# Altium schematic sheet Sheet2.SchDoc(Sheet2)
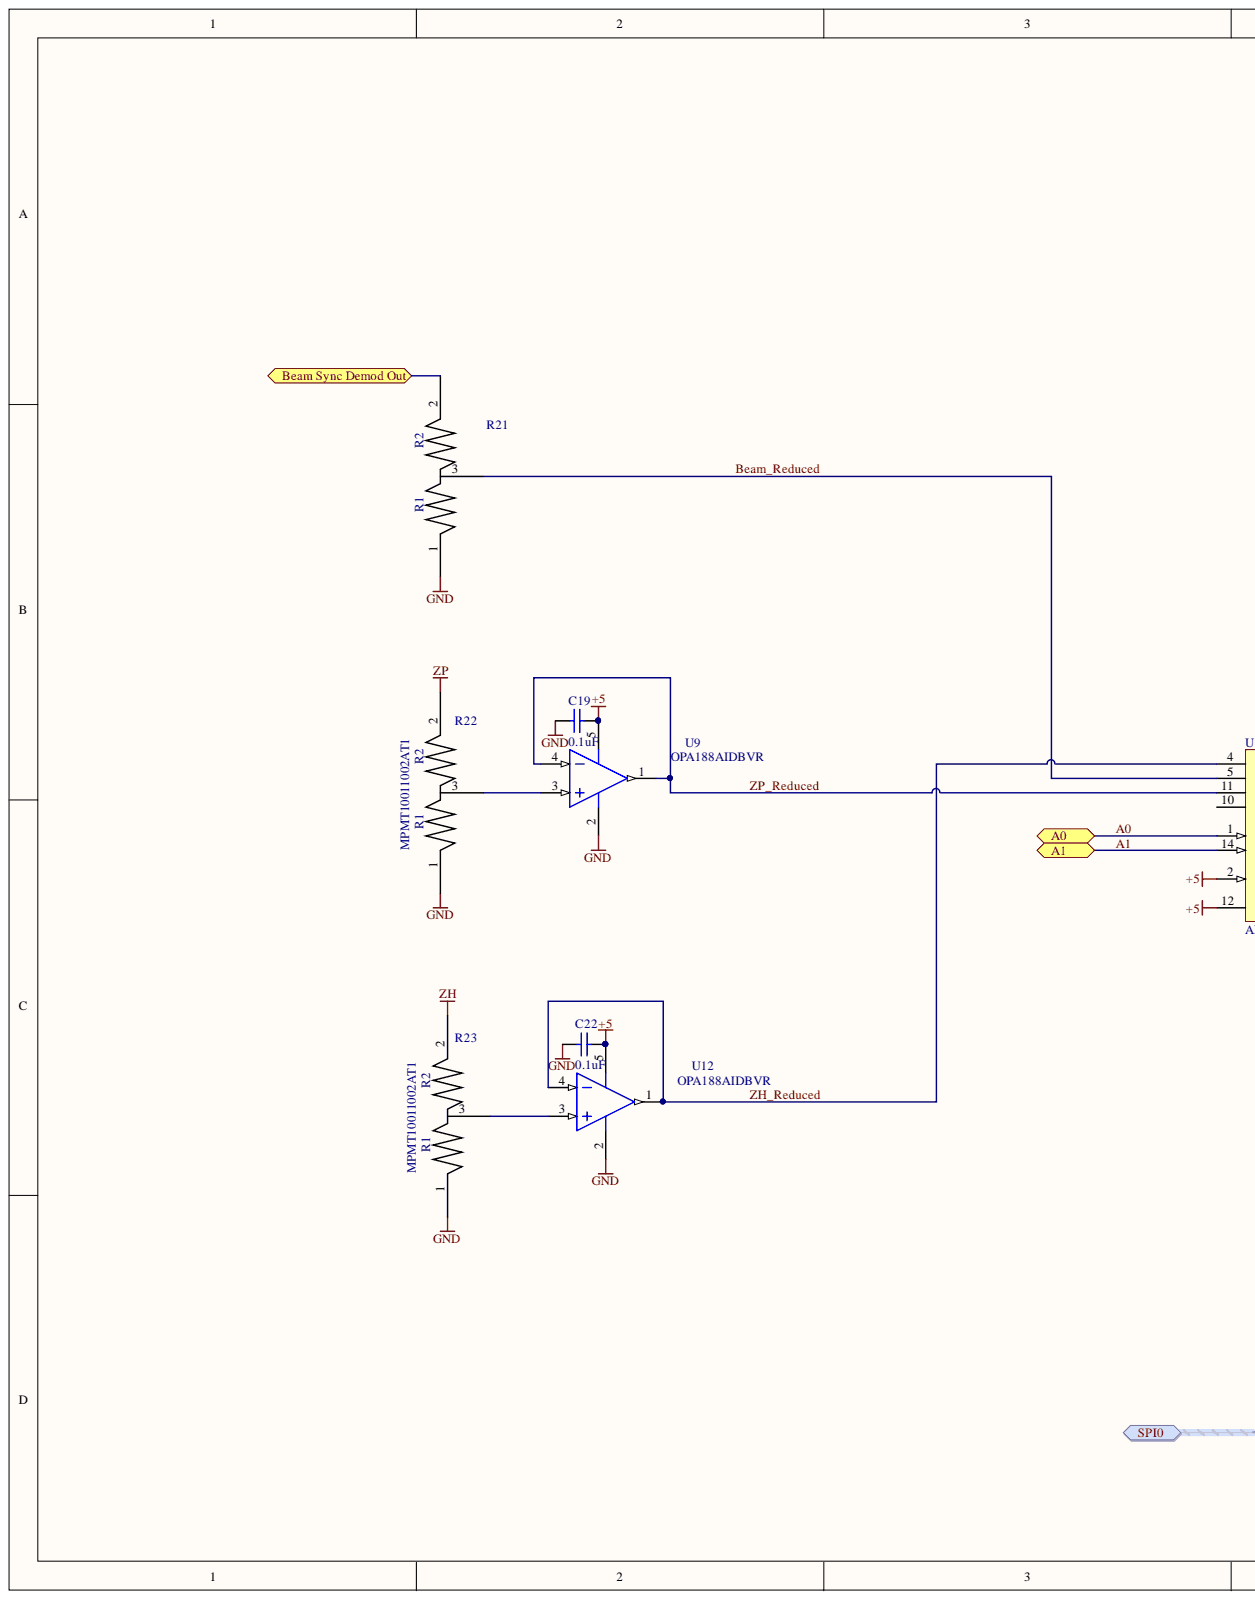
[diagram p0: Sheet2.SchDoc, left]
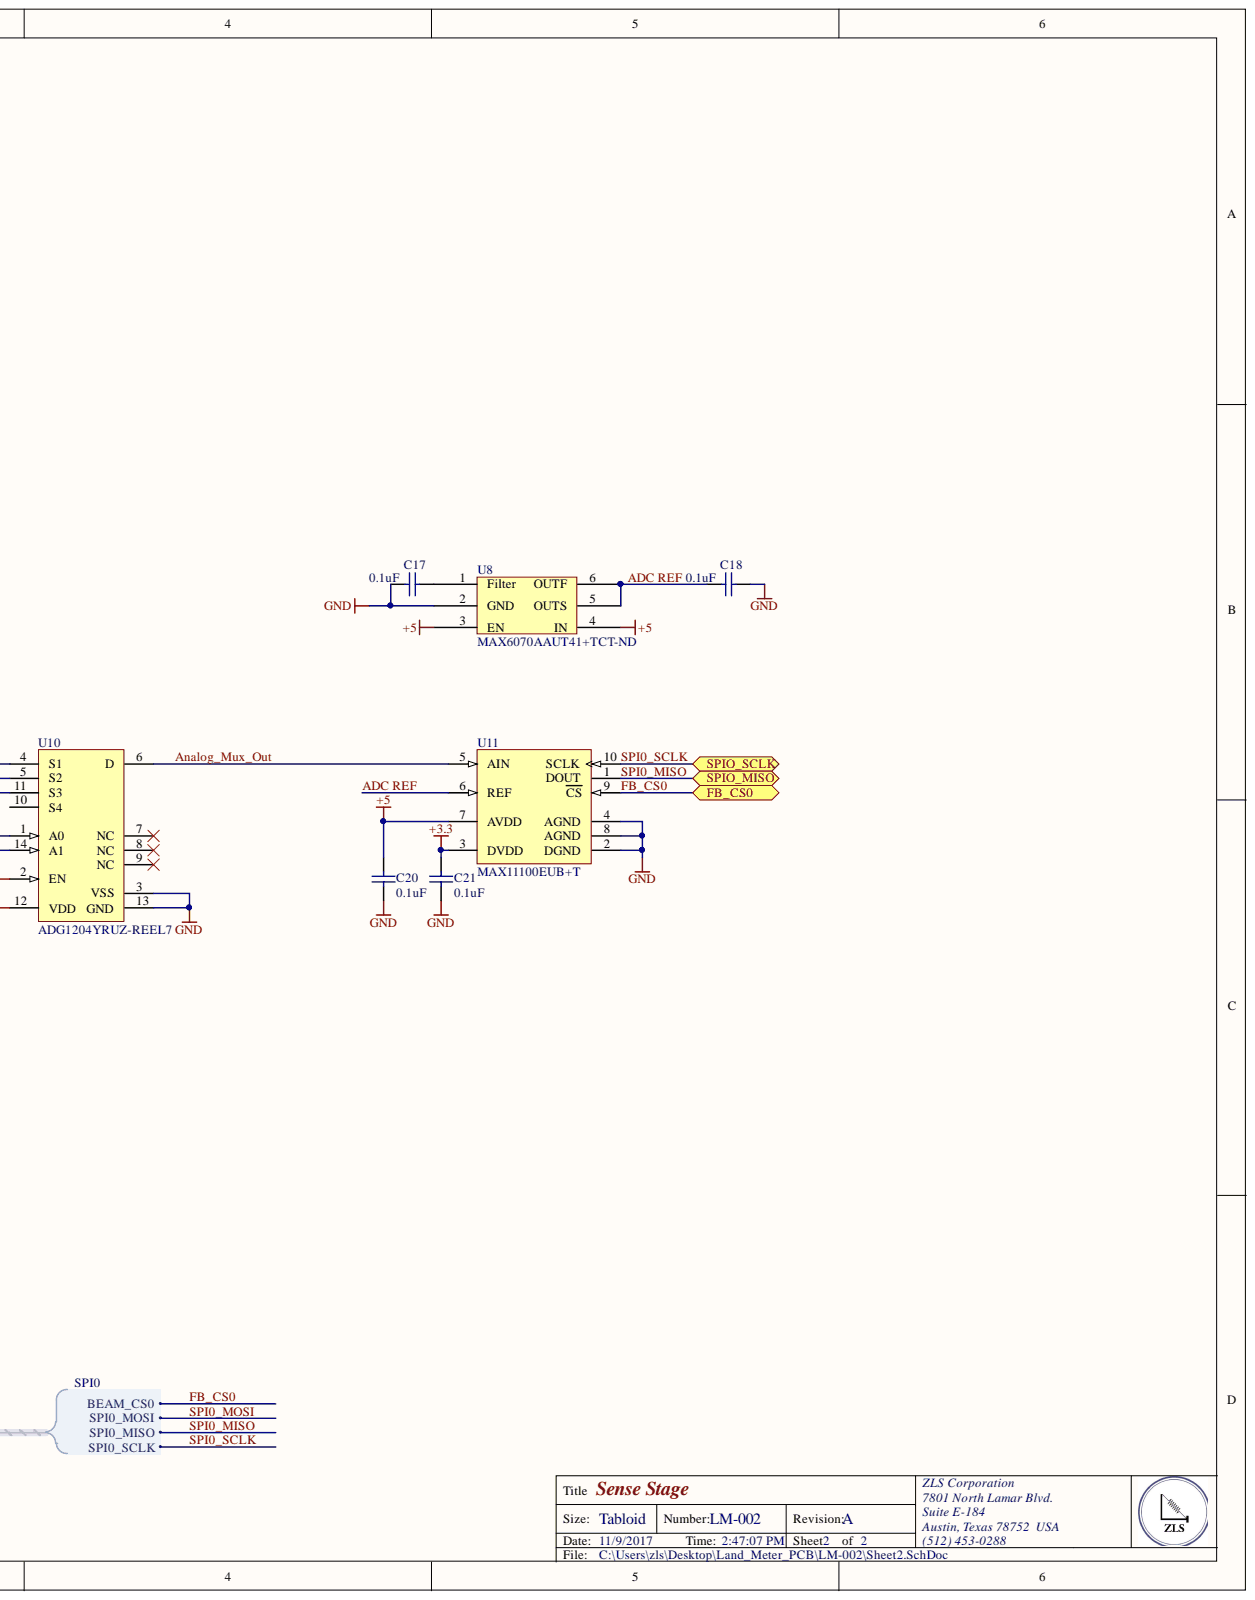
[diagram p1: Sheet2.SchDoc, right]

## Parts
C17  0.1uF  [CAPC0402(1005)60_L]
C18  0.1uF  [CAPC0402(1005)60_L]
C19  0.1uF  [CAPC0402(1005)60_L]
C20  0.1uF  [CAPC0402(1005)60_L]
C21  0.1uF  [CAPC0402(1005)60_L]
C22  0.1uF  [CAPC0402(1005)60_L]
R21  MPM  [SOT95P230X102-3N-MPM]  (value not drawn)
R22  MPMT10011002AT1  [SOT95P230X102-3N-MPM]
R23  MPMT10011002AT1  [SOT95P230X102-3N-MPM]
U8  MAX6070AAUT41+T  Supplier Part Number 1: MAX6070AAUT41+TCT-ND  [SOT95P280X445-6N]
U9  OPA188AIDBVR  [DBV0005A_M]
U10  ADG1204YRUZ-REEL7  [ADI-RU-14_L]
U11  MAX11100EUB+T  [U10+2_L]
U12  OPA188AIDBVR  [DBV0005A_M]

## Ports
A0, A1, Beam Sync Demod Out, FB_CS0, SPI0, SPI0.BEAM_CS0, SPI0.SPI0_MISO, SPI0.SPI0_MOSI, SPI0.SPI0_SCLK, SPIO_MISO, SPIO_SCLK

## Nets
+3.3: C21.1 U11.3(DVDD)
+5: C19.2 C20.1 C22.2 U8.3(EN) U8.4(IN) U9.5 U10.2(EN) U10.12(VDD) U11.7(AVDD) U12.5
GND: C17.2 C18.1 C19.1 C20.2 C21.2 C22.1 R21.1 R22.1 R23.1 U8.2(GND) U9.2 U10.3(VSS) U10.13(GND) U11.2(DGND) U11.4(AGND) U11.8(AGND) U12.2
ZH: R23.2
ZP: R22.2
A0: U10.1(A0) ; port A0
A1: U10.14(A1) ; port A1
ADC REF: C18.2 U8.5(OUTS) U8.6(OUTF) U11.6(REF)
Analog_Mux_Out: U10.6(D) U11.5(AIN)
Beam Sync Demod Out: R21.2 ; port Beam Sync Demod Out
Beam_Reduced: R21.3 U10.5(S2)
FB_CS0: U11.9(CS) ; port FB_CS0, port SPI0
SPI0_MISO: U11.1(DOUT) ; port SPI0, port SPIO_MISO
SPI0_SCLK: U11.10(SCLK) ; port SPI0, port SPIO_SCLK
ZH_Reduced: U10.4(S1) U12.1 U12.4
ZP_Reduced: U9.1 U9.4 U10.11(S3)
NetC17_1: C17.1 U8.1(Filter)
NetR22_3: R22.3 U9.3
NetR23_3: R23.3 U12.3
SPI0_MOSI: ; port SPI0

Not connected: U10 7(NC) 8(NC) 9(NC) 10(S4)

## Library data of the parts
C17-C22 = CMP-1034-00252-1: CAP CER 0.1UF 10V X7R 0402; Manufacturer Part Number: GRM155R71A104KA01D; Manufacturer: Murata Electronics North America; Tolerance: ±10%; Voltage - Rated: 10V; Thickness (Max): 0.022" (0.55mm); Temperature Coefficient: X7R; Supplier Part Number 1: 490-6321-1-ND; Supplier 1: Digi-Key; Stock 1: 2920395; Size / Dimension: 0.039" L x 0.020" W (1.00mm x 0.50mm); RoHS: RoHS Compliant; Ratings: -; Pricing 1: 1=0.1, 10=0.014, 100=0.0063, 500=0.00446, 1000=0.00351, 2500=0.00319, 5000=0.00293 (USD); Part Status: Active; Packaging: Cut Tape (CT); Package / Case: 0402 (1005 Metric); Operating Temperature: -55°C ~ 125°C; Mounting Type: Surface Mount, MLCC; Library Name: Altium Content Vault; Lead Spacing: -; Height - Seated (Max): -; Features: -; ComponentLink1URL: http://www.murata.com/~/media/webrenewal/support/library/catalog/products/capacitor/mlcc/c02e.ashx?la=en-us; ComponentLink1Description: http://www.murata.com/~/media/webrenewal/support/library/catalog/products/capacitor/mlcc/c02e.ashx?la=en-us; Component Kind: Standard; Category: Capacitors; Applications: General Purpose
R22 R23 = MPM: RES NTWRK 2 RES MULT OHM TO236-3; Manufacturer: Vishay Thin Film; Tolerance: ±0.1%; Temperature Coefficient: ±25ppm/°C; Resistance (Ohms): 1k, 10k; Power Per Element: 100mW; Circuit Type: Voltage Divider; Supplier Part Number 1: MPMT-1K/10KCT-ND; Supplier Device Package: SOT-23; Supplier 1: Digi-Key; Stock 1: 4892; Size / Dimension: 0.113" L x 0.051" W (2.86mm x 1.30mm); RoHS: RoHS Compliant; Pricing 1: 1=4.18, 10=3.774, 25=3.4528, 50=3.212, 100=2.8105, 250=2.409, 500=2.20826 (USD); Part Status: Active; Packaging: Cut Tape (CT); Package / Case: TO-236-3, SC-59, SOT-23-3; Operating Temperature: -55°C ~ 125°C; Mounting Type: Surface Mount; Manufacturer Part Number: MPMT10011002AT1; Height - Seated (Max): 0.040" (1.02mm); ComponentLink1URL: http://www.vishay.com/docs/60001/mpm.pdf; ComponentLink1Description: http://www.vishay.com/docs/60001/mpm.pdf; Component Kind: Standard; Category: Resistors; Applications: Voltage Divider (TCR Matched); Library Name: ZLS_Schematic_lib.SchLib
U8 = MAX6070AAUT41+T: IC VREF SERIES 4.096V SOT23; Manufacturer: Maxim Integrated; Voltage - Input: 4.3 V ~ 5.5 V; Tolerance: ±0.04%; Temperature Coefficient: 6ppm/°C; Output Type: Fixed; Noise - 10Hz to 10kHz: 9µVrms; Noise - 0.1Hz to 10Hz: 9.6µVp-p; Current - Supply: 350µA; Voltage - Output (Min/Fixed): 4.096V; Supplier Device Package: SOT-23-6; Supplier 1: Digi-Key; Stock 1: 2623; RoHS: RoHS Compliant; Reference Type: Series; Pricing 1: 1=3.41, 10=3.213, 25=2.5704, 50=2.4418, 100=2.2491, 250=2.13344, 500=2.05634 (USD); Part Status: Active; Packaging: Cut Tape (CT); Package / Case: SOT-23-6; Operating Temperature: -40°C ~ 125°C (TA); Mounting Type: Surface Mount; Manufacturer Part Number: MAX6070AAUT41+T; ComponentLink1URL: https://datasheets.maximintegrated.com/en/ds/MAX6070-MAX6071.pdf; ComponentLink1Description: https://datasheets.maximintegrated.com/en/ds/MAX6070-MAX6071.pdf; Component Kind: Standard; Category: Integrated Circuits (ICs); Library Name: ZLS_Schematic_lib.SchLib
U9 U12 = CMP-0809-00005-2: Precision, Low Noise, Rail-to-Rail Output, Zero-Drift Operational Amplifier, 4 to 36 V, -40 to 125 degC, 5-pin SOT23 (DBV5), Green (RoHS & no Sb/Br); Manufacturer: Texas Instruments; Total Supply Voltage(Min)(+5V is 5, +/-5V is 10): 4; Total Supply Voltage(Max)(+5V is 5, +/-5V is 10): 36; SubFamily: Zero Drift Precision Amplifier; Slew Rate(Typ)(V/us): 0.8; Rating: Catalog; Offset Drift(Typ)(uV/C): 0.03; Number of Channels: 1; Iq per channel(Max)(mA): 0.51; GBW(Typ)(MHz): 2; CMRR(Min)(dB): 120; PartNumber: OPA188AIDBVR; PackageVersion: 4073253-4/K, 03/2006; PackageReference: DBV0005A; PackageDescription: 5-Pin Plastic Small Outline, Body 1.6 x 2.9 mm, Pitch 0.95 mm; Mounting Technology: Surface Mount; Library Name: Altium Content Vault; Eco-Plan: Green (RoHS & no Sb/Br); DatasheetVersion: SBOS642A; ComponentLink3URL: http://www.ti.com/litv/pdf/mpds018i; ComponentLink3Description: Package Specification; ComponentLink2URL: http://www.ti.com/lit/ds/symlink/opa188.pdf; ComponentLink2Description: Datasheet; ComponentLink1URL: http://www.ti.com/; ComponentLink1Description: Manufacturer URL; Component Kind: Standard; Code_JEDEC: MO-178
U10 = CMP-0793-00109-1: Low Capacitance, Low Charge Injection, +/-15 V / +12 V, 4:1 iCMOS Multiplexer, 120 Ohm Ron, 14-pin SOP (RU-14), Reel; Manufacturer: Analog Devices; t transition (s): 120n; Ron (ohms): 120; Max Analog Signal Range: Vss to Vdd; Bandwidth (Hz): 800M, 550M; PartNumber: ADG1204YRUZ-REEL7; Packing: Reel; PackageVersion: Aug-02; PackageReference: RU-14; PackageDescription: 14-Pin Thin Shrink Small Outline Package, Body 5 x 4.4 mm, Pitch 0.65 mm; Mounting Technology: Surface Mount; Library Name: Altium Content Vault; DatasheetVersion: Rev. B; ComponentLink3URL: http://www.analog.com/static/imported-files/packages/36057385283879153717235186773RU14.pdf; ComponentLink3Description: Package Specification; ComponentLink2URL: http://www.analog.com/static/imported-files/data_sheets/ADG1204.pdf; ComponentLink2Description: Datasheet; ComponentLink1URL: http://www.analog.com/en/index.html; ComponentLink1Description: Manufacturer URL; Component Kind: Standard; Code_JEDEC: MO-153AB-1
U11 = CMP-0249-00017-1: 16-Bit, +5V, 200ksps ADC with 10uA Shutdown, 10-Pin uMAX, -40°C to +85°C, Pb-Free, Tape and Reel; PackageVersion: revL, 2008; PackageReference: U10+2; PackageDescription: 10-Pin Micro-MAX Thin Shrink Small Outline (uSOP), 3 x 3 x 0.85 mm Body, 0.5 mm Pitch, Pb-Free; Library Name: Altium Content Vault; DatasheetVersion: Rev 1, Jan-2012; ComponentLink3URL: http://pdfserv.maxim-ic.com/package_dwgs/21-0061.PDF; ComponentLink3Description: Package Specification; ComponentLink2URL: http://datasheets.maxim-ic.com/en/ds/MAX11100.pdf; ComponentLink2Description: Datasheet; ComponentLink1URL: http://www.maxim-ic.com; ComponentLink1Description: Manufacturer URL; Component Kind: Standard; Code_JEDEC: MO-187-BA
R21 = MPM: Component Kind: Standard; Library Name: ZLS_Schematic_lib.SchLib
